# Altium schematic sheet Battery Protection.SchDoc("Battery Protection")
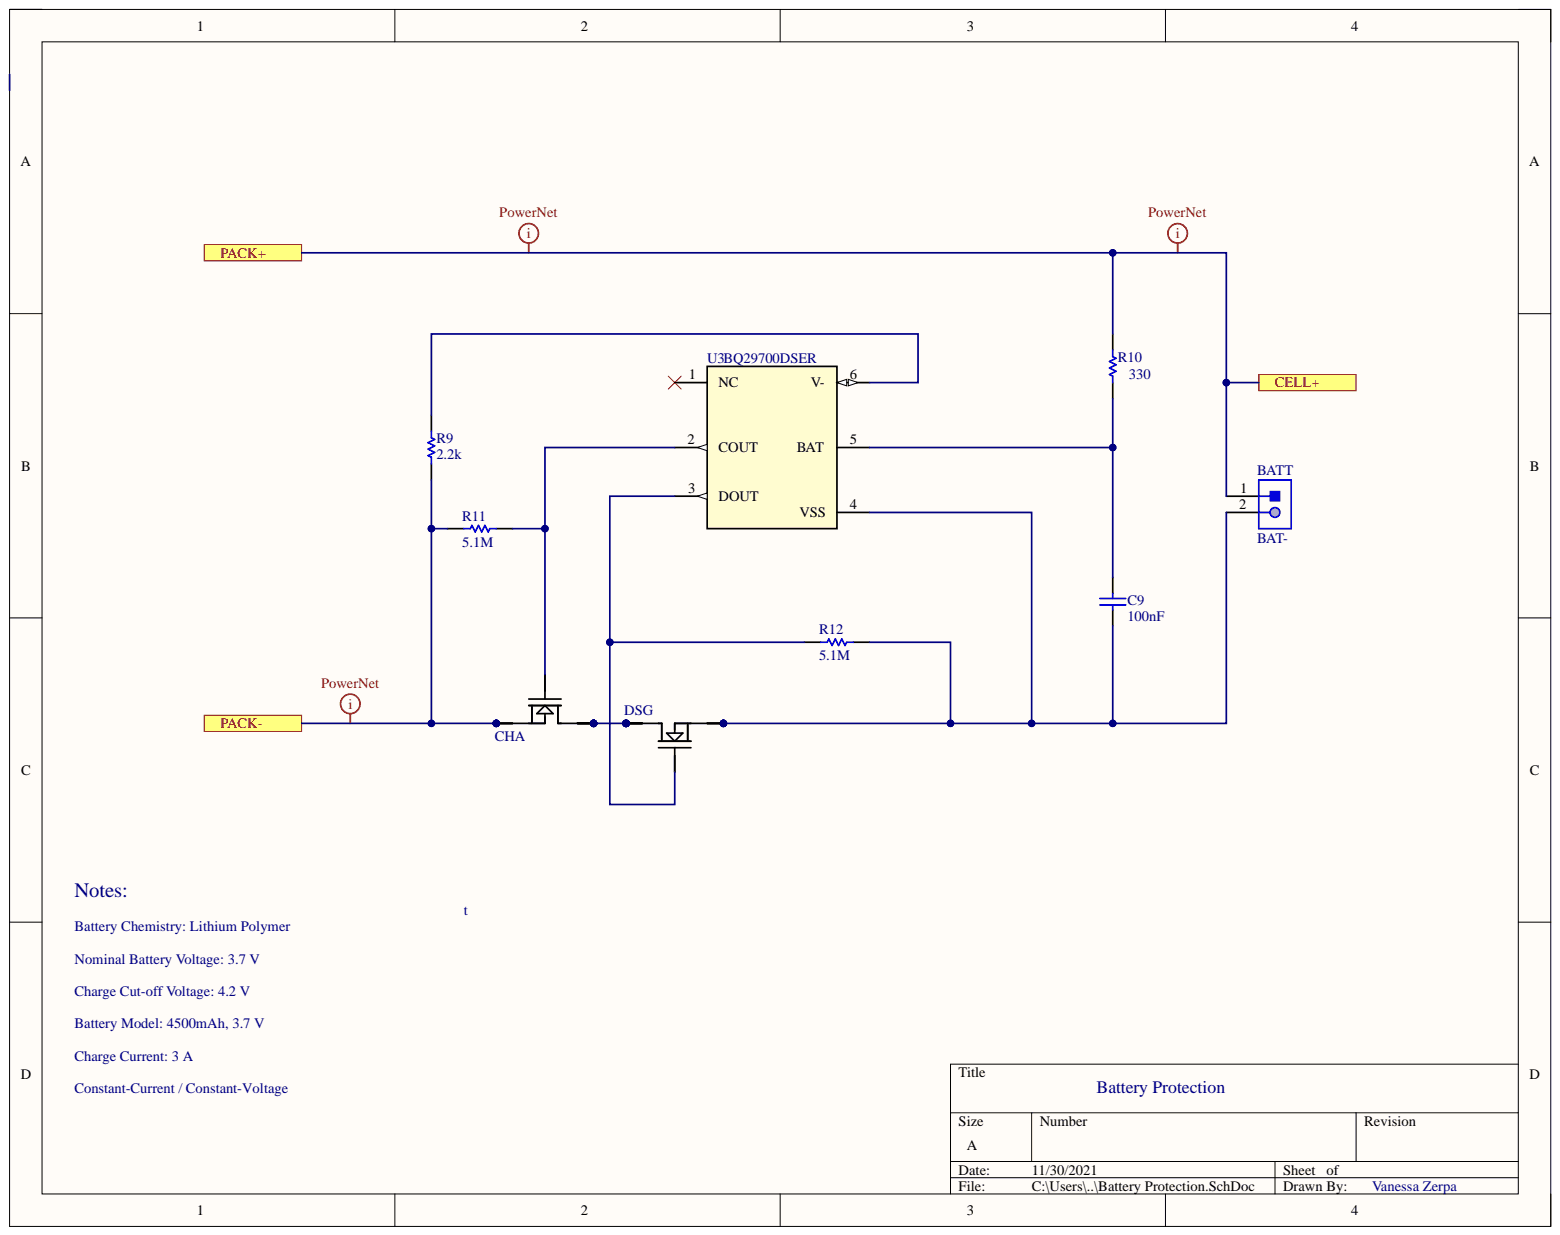
[diagram p0: Battery Protection.SchDoc]

## Parts
BATT  BAT-  [JST-S2B-PH-K-S_V]
C9  100nF  [CAPC2013X94X50NL20T25]
CHA  CSD16406Q3  [DQG0008A]  (value not drawn)
DSG  CSD16406Q3  [DQG0008A]  (value not drawn)
R9  2.2k  [RESC2013X60X40LL20T25]
R10  330  [RESC2013X70X40ML20T20]
R11  5.1M  [RESC2013X70X40ML20T20]
R12  5.1M  [RESC2013X70X40ML20T20]
U3  BQ29700DSER  [DSE0006A]

## Ports
CELL+, PACK+, PACK-

## Nets
CELL+: BATT.1 R10.2 ; port CELL+
NetBATT_2: BATT.2 C9.1 DSG.1 DSG.2 DSG.3 R12.2 U3.4(VSS)
NetC9_2: C9.2 R10.1 U3.5(BAT)
NetCHA_1: CHA.1 CHA.2 CHA.3 R9.1 R11.1
NetCHA_4: CHA.4 R11.2 U3.2(COUT)
NetCHA_5: CHA.5 CHA.6 CHA.7 CHA.8 CHA.9 DSG.5 DSG.6 DSG.7 DSG.8 DSG.9
NetDSG_4: DSG.4 R12.1 U3.3(DOUT)
NetR9_2: R9.2 U3.6(V-)

Not connected: U3 1(NC)

## Library data of the parts
BATT = CMP-1755-00004-1: Male Header, Pitch 2 mm, 1 x 2 Position, Height 4.8 mm, Tail Length 3.4 mm, -25 to 85 degC, RoHS, Bulk; Capacitance: 10 uF; Case\Package: Radial; Tolerance: 20%; Voltage Rating: 50 V; Component Kind: Standard; ComponentLink1Description: Datasheet; ComponentLink1URL: http://www.jst-mfg.com/product/pdf/eng/ePH.pdf; DatasheetVersion: Dec-12; Diameter: 5 mm; Height: 11 mm; Lead Pitch: 2 mm; Length: 11 mm; Library Name: Altium Content Vault; Max Operating Temperature: 85 degC; Min Operating Temperature: -40 degC; Mount: Through Hole; Package Quantity: 200; PackageDescription: Male Header, Pitch 2 mm, 1 x 2 Position, Height 4.8 mm, Tail Length 3.4 mm; PackageReference: S2B-PH-K-S; Packaging: Bulk; Pins: 2; Radiation Hardening: No; REACH SVHC: No SVHC; RoHSCompliant: true; Voltage Rating (DC): 50 V
C9 = CMP-1036-04419-2: Manufacturer: AVX Interconnect / Elco; Part Number: 08055C104KAT4A; Component Kind: Standard; Library Name: Altium Content Vault
CHA DSG = CSD16406Q3: No Description Available; Mfr_Name: Texas Instruments; Component Kind: Standard; Library Name: MOSFET.SchLib; Manufacturer_Part_Number: CSD16406Q3; RefDes: RefDes; Type: DEV; Copyright: Copyright (C) 2021 Ultra Librarian. All rights reserved.
R9 = CMP-2001-00613-1: Manufacturer: Panasonic; Part Number: ERA6AEB222V; Component Kind: Standard; Library Name: Altium Content Vault
R10 = CMP-1013-00062-2: Manufacturer: Panasonic; Part Number: ERJ-6GEYJ331V; Component Kind: Standard; Library Name: Altium Content Vault
R11 R12 = CMP-2001-00430-1: Manufacturer: Panasonic; Part Number: ERJ-6GEYJ515V; Component Kind: Standard; Library Name: Altium Content Vault
U3 = BQ29700DSER: No Description Available; Mfr_Name: Texas Instruments; Component Kind: Standard; Library Name: BatteryProtection.SchLib; Manufacturer_Part_Number: BQ29700DSER; RefDes: RefDes; Type: DEV; Copyright: Copyright (C) 2021 Ultra Librarian. All rights reserved.
